# Altium schematic sheet CUBETTO-RELE.SchDoc(CUBETTO-RELE)
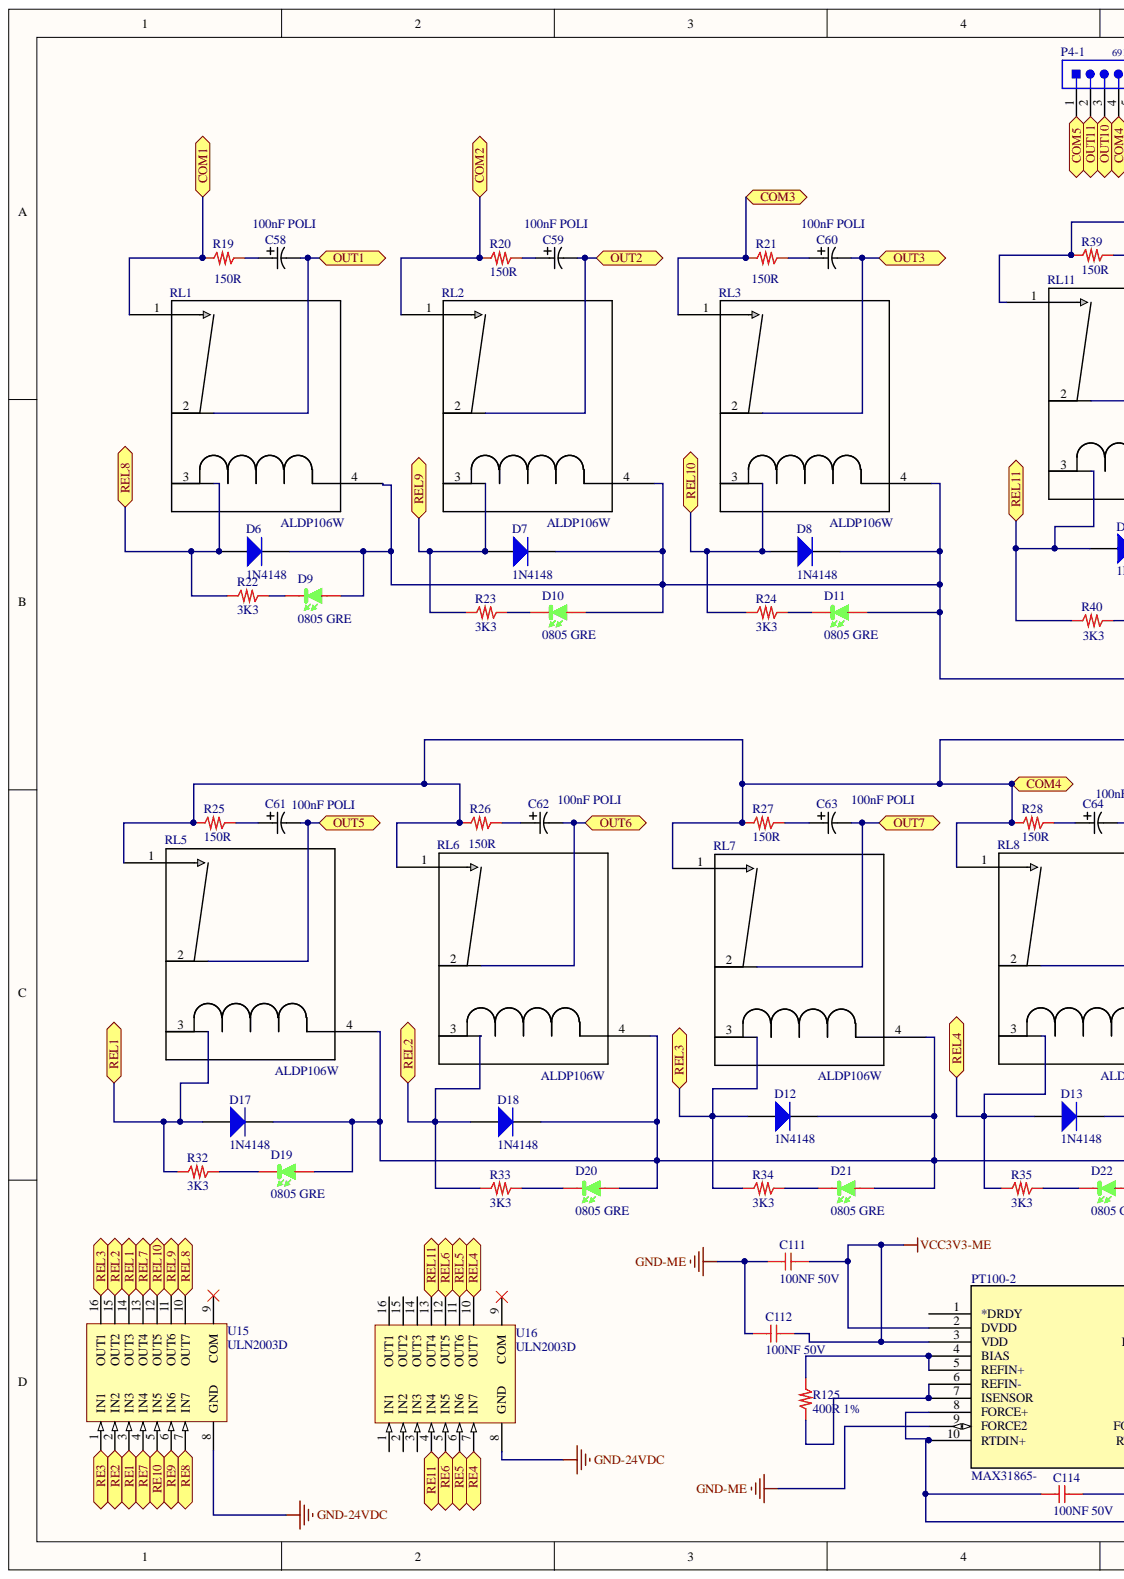
[diagram p0: CUBETTO-RELE.SchDoc, left]
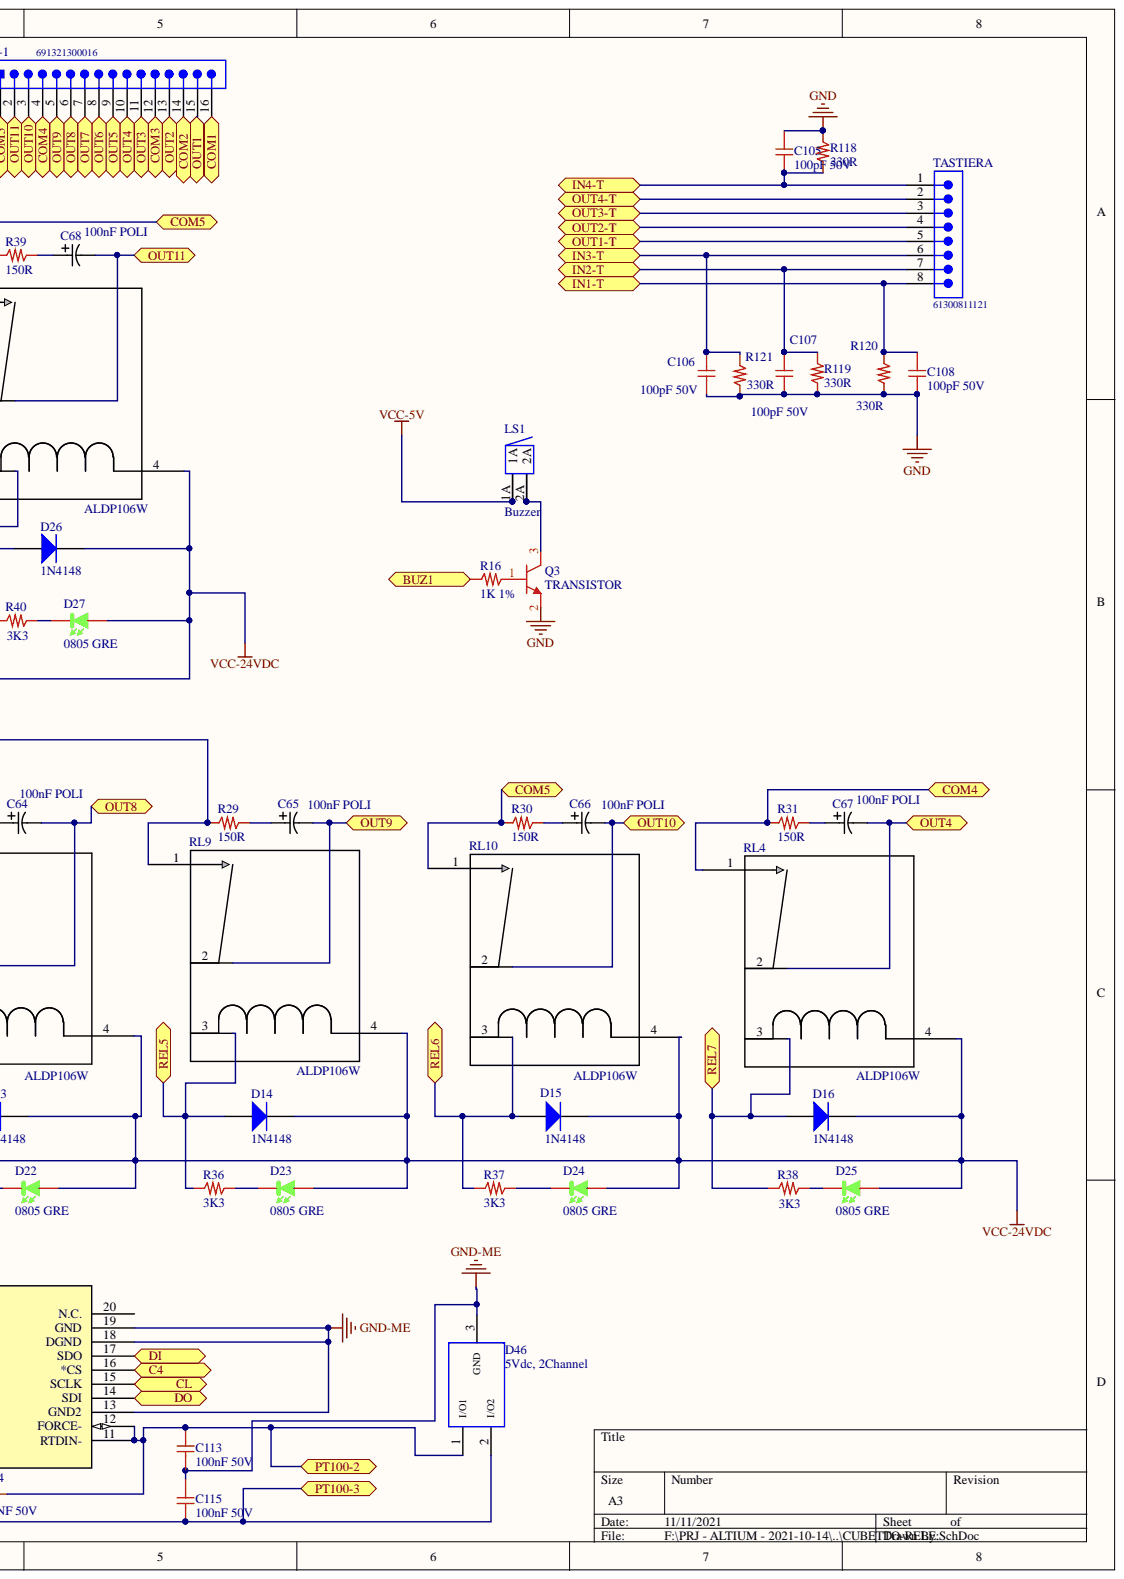
[diagram p1: CUBETTO-RELE.SchDoc, right]

## Parts
C58  100nF POLI  [CAP-SCATOLINO-5]
C59  100nF POLI  [CAP-SCATOLINO-5]
C60  100nF POLI  [CAP-SCATOLINO-5]
C61  100nF POLI  [CAP-SCATOLINO-5]
C62  100nF POLI  [CAP-SCATOLINO-5]
C63  100nF POLI  [CAP-SCATOLINO-5]
C64  100nF POLI  [CAP-SCATOLINO-5]
C65  100nF POLI  [CAP-SCATOLINO-5]
C66  100nF POLI  [CAP-SCATOLINO-5]
C67  100nF POLI  [CAP-SCATOLINO-5]
C68  100nF POLI  [CAP-SCATOLINO-5]
C105  100pF 50V  [0402_C]
C106  100pF 50V  [0402_C]
C107  100pF 50V  [0402_C]
C108  100pF 50V  [0402_C]
C111  100NF 50V  [0603_c]
C112  100NF 50V  [0603_c]
C113  100nF 50V  [0603_c]
C114  100NF 50V  [0603_c]
C115  100nF 50V  [0603_c]
D6  1N4148  [1N4148]
D7  1N4148  [1N4148]
D8  1N4148  [1N4148]
D9  0805 GRE  [0805_Green]
D10  0805 GRE  [0805_Green]
D11  0805 GRE  [0805_Green]
D12  1N4148  [1N4148]
D13  1N4148  [1N4148]
D14  1N4148  [1N4148]
D15  1N4148  [1N4148]
D16  1N4148  [1N4148]
D17  1N4148  [1N4148]
D18  1N4148  [1N4148]
D19  0805 GRE  [0805_Green]
D20  0805 GRE  [0805_Green]
D21  0805 GRE  [0805_Green]
D22  0805 GRE  [0805_Green]
D23  0805 GRE  [0805_Green]
D24  0805 GRE  [0805_Green]
D25  0805 GRE  [0805_Green]
D26  1N4148  [1N4148]
D27  0805 GRE  [0805_Green]
D46  5Vdc, 2Channel  [WE-TVS_Standard_SOT23-3L]
LS1  Buzzer  [BUZZER-14mm]
P4-1  691321300016  [691321300016]
PT100-2  MAX31865-  [SSO-20]
Q3  TRANSISTOR  [SOT23]
R16  1K 1%  [0603_R]
R19  150R  [1206_R]
R20  150R  [1206_R]
R21  150R  [1206_R]
R22  3K3  [1206_R]
R23  3K3  [1206_R]
R24  3K3  [1206_R]
R25  150R  [1206_R]
R26  150R  [1206_R]
R27  150R  [1206_R]
R28  150R  [1206_R]
R29  150R  [1206_R]
R30  150R  [1206_R]
R31  150R  [1206_R]
R32  3K3  [1206_R]
R33  3K3  [1206_R]
R34  3K3  [1206_R]
R35  3K3  [1206_R]
R36  3K3  [1206_R]
R37  3K3  [1206_R]
R38  3K3  [1206_R]
R39  150R  [1206_R]
R40  3K3  [1206_R]
R118  330R  [0402_R]
R119  330R  [0402_R]
R120  330R  [0402_R]
R121  330R  [0402_R]
R125  400R 1%  [0805_R]
RL1  ALDP106W  [PAN_ALD]
RL2  ALDP106W  [PAN_ALD]
RL3  ALDP106W  [PAN_ALD]
RL4  ALDP106W  [PAN_ALD]
RL5  ALDP106W  [PAN_ALD]
RL6  ALDP106W  [PAN_ALD]
RL7  ALDP106W  [PAN_ALD]
RL8  ALDP106W  [PAN_ALD]
RL9  ALDP106W  [PAN_ALD]
RL10  ALDP106W  [PAN_ALD]
RL11  ALDP106W  [PAN_ALD]
TASTIERA  61300811121  [61300811121]
U15  ULN2003D  [SO16_N]
U16  ULN2003D  [SO16_N]

## Ports
BUZ1, C4, CL, COM1, COM2, COM3, COM4, COM5, DI, DO, IN1-T, IN2-T, IN3-T, IN4-T, OUT1, OUT1-T, OUT2, OUT2-T, OUT3, OUT3-T, OUT4, OUT4-T, OUT5, OUT6, OUT7, OUT8, OUT9, OUT10, OUT11, PT100-2, PT100-3, RE1, RE2, RE3, RE4, RE5, RE6, RE7, RE8, RE9, RE10, RE11, REL1, REL2, REL3, REL4, REL5, REL6, REL7, REL8, REL9, REL10, REL11

## Nets
GND: C105.1 C106.2 C107.2 C108.2 Q3.2 R118.1 R119.2 R120.2 R121.2
GND-24VDC: U15.8(GND) U16.8(GND)
GND-ME: C111.2 C112.2 C113.2 C115.1 D46.3 PT100-2.9(FORCE2) PT100-2.13(GND2) PT100-2.18(DGND) PT100-2.19(GND)
VCC3V3-ME: C111.1 C112.1 PT100-2.2(DVDD) PT100-2.3(VDD)
VCC-5V: LS1.1(1A) LS1.1A
VCC-24VDC: D6.2 D7.2 D8.2 D9.A D10.A D11.A D12.2 D13.2 D14.2 D15.2 D16.2 D17.2 D18.2 D19.A D20.A D21.A D22.A D23.A D24.A D25.A D26.2 D27.A RL1.4 RL2.4 RL3.4 RL4.4 RL5.4 RL6.4 RL7.4 RL8.4 RL9.4 RL10.4 RL11.4
BUZ1: R16.1 ; port BUZ1
C4: PT100-2.16(*CS) ; port C4
CL: PT100-2.15(SCLK) ; port CL
COM1: P4-1.16 R19.1 RL1.1 ; port COM1
COM2: P4-1.14 R20.1 RL2.1 ; port COM2
COM3: P4-1.12 R21.1 RL3.1 ; port COM3
COM4: P4-1.4 R25.1 R26.1 R27.1 R28.1 R29.1 R31.1 RL4.1 RL5.1 RL6.1 RL7.1 RL8.1 RL9.1 ; port COM4
COM5: P4-1.1 R30.1 R39.1 RL10.1 RL11.1 ; port COM5
DI: PT100-2.17(SDO) ; port DI
DO: PT100-2.14(SDI) ; port DO
IN1-T: C108.1 R120.1 TASTIERA.8 ; port IN1-T
IN2-T: C107.1 R119.1 TASTIERA.7 ; port IN2-T
IN3-T: C106.1 R121.1 TASTIERA.6 ; port IN3-T
IN4-T: C105.2 R118.2 TASTIERA.1 ; port IN4-T
OUT1: C58.2 P4-1.15 RL1.2 ; port OUT1
OUT1-T: TASTIERA.5 ; port OUT1-T
OUT2: C59.2 P4-1.13 RL2.2 ; port OUT2
OUT2-T: TASTIERA.4 ; port OUT2-T
OUT3: C60.2 P4-1.11 RL3.2 ; port OUT3
OUT3-T: TASTIERA.3 ; port OUT3-T
OUT4: C67.2 P4-1.10 RL4.2 ; port OUT4
OUT4-T: TASTIERA.2 ; port OUT4-T
OUT5: C61.2 P4-1.9 RL5.2 ; port OUT5
OUT6: C62.2 P4-1.8 RL6.2 ; port OUT6
OUT7: C63.2 P4-1.7 RL7.2 ; port OUT7
OUT8: C64.2 P4-1.6 RL8.2 ; port OUT8
OUT9: C65.2 P4-1.5 RL9.2 ; port OUT9
OUT10: C66.2 P4-1.3 RL10.2 ; port OUT10
OUT11: C68.2 P4-1.2 RL11.2 ; port OUT11
PT100-2: C113.1 C114.1 D46.1 PT100-2.11(RTDIN-) PT100-2.12(FORCE-) ; port PT100-2
PT100-3: C114.2 C115.2 D46.2 PT100-2.8(FORCE+) PT100-2.10(RTDIN+) ; port PT100-3
RE1: U15.3(IN3) ; port RE1
RE2: U15.2(IN2) ; port RE2
RE3: U15.1(IN1) ; port RE3
RE4: U16.7(IN7) ; port RE4
RE5: U16.6(IN6) ; port RE5
RE6: U16.5(IN5) ; port RE6
RE7: U15.4(IN4) ; port RE7
RE8: U15.7(IN7) ; port RE8
RE9: U15.6(IN6) ; port RE9
RE10: U15.5(IN5) ; port RE10
RE11: U16.4(IN4) ; port RE11
REL1: D17.1 R32.1 RL5.3 U15.14(OUT3) ; port REL1
REL2: D18.1 R33.1 RL6.3 U15.15(OUT2) ; port REL2
REL3: D12.1 R34.1 RL7.3 U15.16(OUT1) ; port REL3
REL4: D13.1 R35.1 RL8.3 U16.10(OUT7) ; port REL4
REL5: D14.1 R36.1 RL9.3 U16.11(OUT6) ; port REL5
REL6: D15.1 R37.1 RL10.3 U16.12(OUT5) ; port REL6
REL7: D16.1 R38.1 RL4.3 U15.13(OUT4) ; port REL7
REL8: D6.1 R22.1 RL1.3 U15.10(OUT7) ; port REL8
REL9: D7.1 R23.1 RL2.3 U15.11(OUT6) ; port REL9
REL10: D8.1 R24.1 RL3.3 U15.12(OUT5) ; port REL10
REL11: D26.1 R40.1 RL11.3 U16.13(OUT4) ; port REL11
NetC58_1: C58.1 R19.2
NetC59_1: C59.1 R20.2
NetC60_1: C60.1 R21.2
NetC61_1: C61.1 R25.2
NetC62_1: C62.1 R26.2
NetC63_1: C63.1 R27.2
NetC64_1: C64.1 R28.2
NetC65_1: C65.1 R29.2
NetC66_1: C66.1 R30.2
NetC67_1: C67.1 R31.2
NetC68_1: C68.1 R39.2
NetD9_K: D9.K R22.2
NetD10_K: D10.K R23.2
NetD11_K: D11.K R24.2
NetD19_K: D19.K R32.2
NetD20_K: D20.K R33.2
NetD21_K: D21.K R34.2
NetD22_K: D22.K R35.2
NetD23_K: D23.K R36.2
NetD24_K: D24.K R37.2
NetD25_K: D25.K R38.2
NetD27_K: D27.K R40.2
NetLS1_2: LS1.2(2A) LS1.2A Q3.3
NetPT100-2_4: PT100-2.4(BIAS) PT100-2.5(REFIN+) R125.1
NetPT100-2_6: PT100-2.6(REFIN-) PT100-2.7(ISENSOR) R125.2
NetQ3_1: Q3.1 R16.2

Not connected: PT100-2 1(*DRDY) 20(N.C.); U15 9(COM); U16 1(IN1) 2(IN2) 3(IN3) 9(COM) 14(OUT3) 15(OUT2) 16(OUT1)

## Library data of the parts
C58-C68 = CAP-SCATOLINO: No Description Available; Manufacturer_Part_Number: R82DC3470DQ60J; Vendor: Arcotronics; Type: DEV; Built_By: EMA_UL_Team; Value: Value; RefDes: RefDes; Component Kind: Standard; Library Name: HSW.SchLib; Copyright: Copyright (C) 2018 Accelerated Designs. All rights reserved
C105-C108 = 100pF 50V: Cap COG 100pF 50V; Produttore: ??; Cod. Interno: COCS506565000101; Library Name: CapSMD0402.SchLib; Component Kind: Standard; Cod. Produttore: ??
C111-C115 = 100NF 50V: Cap X7R 100nF 50V Flex Terminal; Produttore: Samsung; Cod. Produttore: CL10B104KB8SFNC; Cod. Interno: COCS50665050104; Library Name: CapSMD0603.SchLib; Component Kind: Standard; Cod. DigiKey: 1276-1936-2-ND
D6-D8 D12-D18 D26 = 1N4148: High Conductance Fast Diode; Published: 8-Jun-2000; PackageReference: DO-35; PackageDescription: Diode, Axial; Body 3.8 x 1.9 mm (LxDia. max), Lead Dia. 0.53 mm (max); LatestRevisionNote: Re-released for DXP Platform.; LatestRevisionDate: 17-Jul-2002; DatasheetVersion: 1997; ComponentLink2URL: http://www.fairchildsemi.com/ds/1N%2F1N4148.pdf; ComponentLink2Description: Datasheet; ComponentLink1URL: http://www.fairchildsemi.com/; ComponentLink1Description: Manufacturer Link; Component Kind: Standard; Code_JEDEC: DO-204-AH; Publisher: Altium Limited; Library Name: HSW.SchLib
D9-D11 D19-D25 D27 = LED_Verde_0805: LED Verde 0805; V: 3.1V; Produttore: WE; Luminosità: 450mcd; Cod. Produttore: 150080GS75000; Cod. Interno: LEDS 9AC080GS7500; Component Kind: Standard; Library Name: DiodiLED.SchLib
D46 = 824022: WE-TVS TVS Diode - Standard Series, SOT23-3L, 5Vdc, 2 Channel; Reverse Peak Pulse Current: 8A; Reverse Channel Leakage Current: 2.5uA; Reverse Breakdown Voltage min.: 6.1V; DC Operating Voltage: 5V; Channel Input Capacitance: 12pF; Channel: 2; Size: SOT23-3L; Published Date: June 2019; Part Number: 824022; Packaging: Tape and Reel; Operating Temperature: -55 to +125degC; Mounting Technology: SMT; Library Name: WE-TVS.SchLib; ComponentLink2URL: https://katalog.we-online.com/pbs/datasheet/824022.pdf; ComponentLink2Description: Datasheet; ComponentLink1URL: http://www.we-online.de; ComponentLink1Description: Manufacturer; Component Kind: Standard; Publisher: Wurth Elektronik
LS1 = Buzzer: Magnetic Transducer Buzzer; Published: 24-Mar-1999; PackageReference: ABSM-1574; PackageDescription: Magnetic Transducer Buzzer; LatestRevisionNote: Schematic revised, PCB Footprint replaced.; LatestRevisionDate: 17-Dec-2003; DatasheetVersion: 17-Dec-2003; ComponentLink1URL: http://www.megastar.com/linecard/buzzmode/index.php; ComponentLink1Description: Manufacturer Link; Component Kind: Standard; Publisher: Altium Limited; Library Name: HSW.SchLib
P4-1 = 691321300016: Serie 321 - 3.81mm Vertical PCB Header WR-TBL, 16 pin; Pin Number: 16; Gender: Male; Published Date: June 2018; Pitch: 3.81 mm; Part Number: 691321300016; Packaging: Box; Operating Temperature: -40 to 105 degC; Mounting Technology: THT; Library Name: Pluggable PCB System WR-TBL_3.81 mm.SchLib; ComponentLink2URL: http://katalog.we-online.de/em/datasheet/6913213000xx.pdf; ComponentLink2Description: Datasheet; ComponentLink1URL: http://www.we-online.de; ComponentLink1Description: Manufacturer; Component Kind: Standard; Publisher: Wurth Elektronik
PT100-2 = MAX31865AAP+T: PT100-PT1000- SENSOR TEMPERATURE; Vendor: Maxim Integrated Products; Built_By: EMA_UL_Team; Value: Value; Type: DEV; RefDes: RefDes; Manufacturer_Part_Number: MAX31865AAP+T; Component Kind: Standard; Library Name: HSW.SchLib; Copyright: Copyright (C) 2018 Accelerated Designs. All rights reserved
Q3 = BC847B: NPN Silicon Darlington Transistors; Vce: 30V; Vcb: 40V; Produttore: INFINEON; Ic: 500mA; Cod. Produttore: BCV27; Cod. Interno: TRXS ??; Component Kind: Standard; Library Name: HSW.SchLib
R16 = 1K 1%: Res Film Spesso 1/16W 1K 1%; Produttore: ??; Cod. Interno: RESS4166356B0102; Library Name: ResSMD0603.SchLib; Component Kind: Standard; Cod. Produttore: ??
R19-R21 R25-R31 R39 = 150R: 150R 1206 1/4W; Library Name: ResSMD1206.SchLib; Component Kind: Standard; Case: 1206
R22-R24 R32-R38 R40 = 1K8: 1K8 1206 1/4W; Library Name: ResSMD1206.SchLib; Component Kind: Standard; Case: 1206
R118-R121 = 330R: Res Film Spesso 1/16W 330R 5%; Produttore: ??; Cod. Interno: RESS4166560C0121; Library Name: ResSMD0402.SchLib; Component Kind: Standard; Cod. Produttore: ??
R125 = 400R 1%: Res Film Spesso 100mW 400R 1%; V nominale: 100V; Produttore: Panasonic; Cod. Produttore: ERA6AEB301V; Cod. Interno: ??; Potenza: 100mW; Library Name: ResSMD0805.SchLib; Component Kind: Standard; Cod. Farnell: 1670221
RL1-RL11 = ALDP106W: No Description Available; Vendor: Panasonic; Built_By: EMA_UL_Team; Value: Value; Type: DEV; RefDes: RefDes; Manufacturer_Part_Number: ALDP106W; Component Kind: Standard; Library Name: FTR-F3.SchLib; Copyright: Copyright (C) 2018 Accelerated Designs. All rights reserved
TASTIERA = 61300811121: THT Vertical Pin Header WR-PHD, Pitch 2.54 mm, Single Row, 8 pins; Working Voltage: 250 VAC; Withstanding Voltage: 500 VAC; Rated Current: 3 A; Pitch: 2.54 mm; Pin Number: 8; Gender: Male; Contact Resistance: 20 mOhm; Row Number: Single; Published Date: August 2018; Part Number: 61300811121; Packaging: Bag; Orientation: Vertical; Operating Temperature: -40 to 105 degC; Mounting Technology: THT; Library Name: Pin Header WR-PHD_2.54 mm.SchLib; ComponentLink2URL: https://katalog.we-online.de/em/datasheet/6130xx11121.pdf; ComponentLink2Description: Datasheet; ComponentLink1URL: http://www.we-online.de; ComponentLink1Description: Manufacturer; Component Kind: Standard; Publisher: Wurth Elektronik
U15 U16 = ULN2003D: Seven Darlington Array; Published: 8-Jun-2000; PackageVersion: May-1998; PackageReference: SO16; PackageDescription: 16-Pin Small Outline Integrated Circuit 1.27 mm Pitch; LatestRevisionNote: IPC-7351 Footprint Added.; LatestRevisionDate: 13-Apr-2006; DatasheetVersion: Sep-1998; ComponentLink2URL: http://www.st.com/stonline/products/literature/ds/5279/uln2001.pdf; ComponentLink2Description: Datasheet; ComponentLink1URL: http://www.st.com/; ComponentLink1Description: Manufacturer Link; Component Kind: Standard; Code_IPC: SOIC127P600-16; Publisher: Altium Limited; Library Name: HSW.SchLib
